# Altium schematic sheet Nano.SchDoc(Nano)
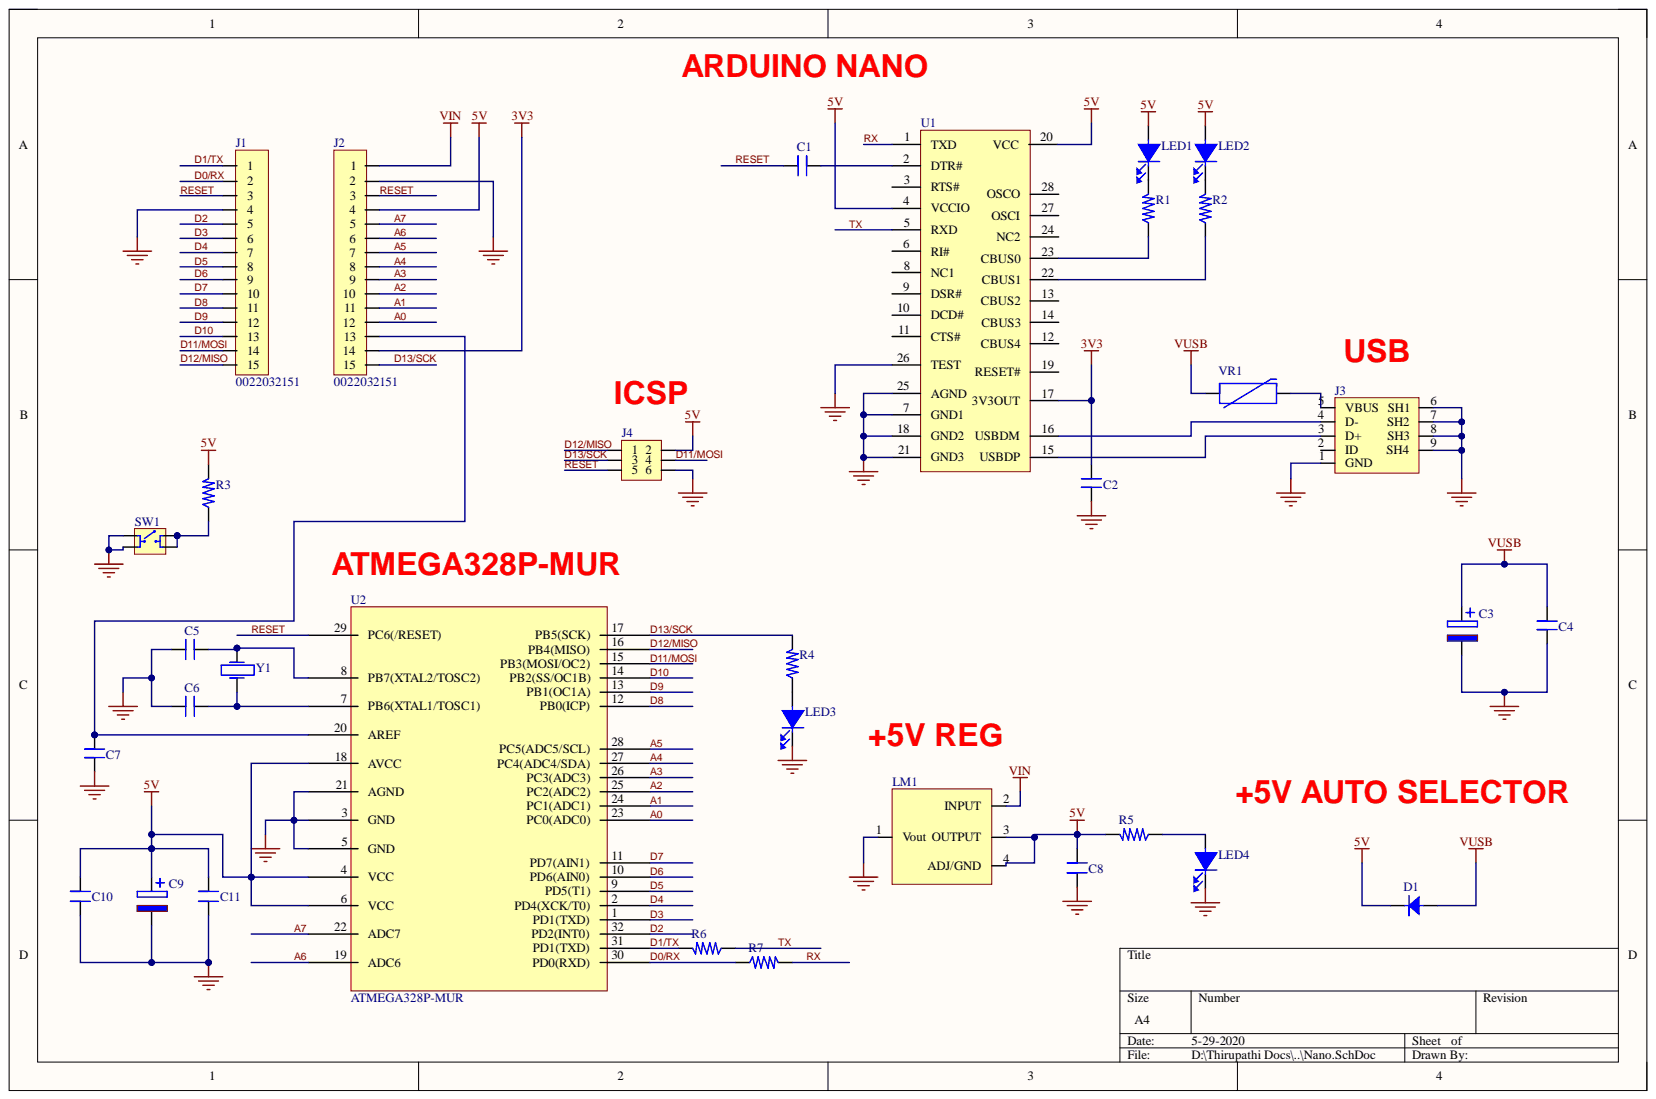
[diagram p0: Nano.SchDoc]

## Parts
C1  C2012X7R1H104K085AA  [c0805]  (value not drawn)
C2  C2012X7R1H104K085AA  [c0805]  (value not drawn)
C3  CC0805KKX5R7BB475  [c0805]  (value not drawn)
C4  C2012X7R1H104K085AA  [c0805]  (value not drawn)
C5  C2012X7R1H104K085AA  [c0805]  (value not drawn)
C6  C2012X7R1H104K085AA  [c0805]  (value not drawn)
C7  C2012X7R1H104K085AA  [c0805]  (value not drawn)
C8  CC0805JKX7R7BB105  [c0805]  (value not drawn)
C9  CC0805KKX5R7BB475  [c0805]  (value not drawn)
C10  CC0805JKX7R7BB105  [c0805]  (value not drawn)
C11  CC0805JKX7R7BB105  [c0805]  (value not drawn)
D1  MSS1P3L-M3/89A  [diode]  (value not drawn)
J1  0022032151  [header1*15]
J2  0022032151  [header1*15]
J3  1734035-2  [USB]  (value not drawn)
J4  67997-106HLF  [HEADER 2*3]  (value not drawn)
LED1  LGL29K-G2J1-24-Z  [LED]  (value not drawn)
LED2  LGL29K-G2J1-24-Z  [LED]  (value not drawn)
LED3  LGL29K-G2J1-24-Z  [LED]  (value not drawn)
LED4  LGL29K-G2J1-24-Z  [LED]  (value not drawn)
LM1  LM1117IMPX-5.0/NOPB  [SOP4]  (value not drawn)
R1  SR0805JR-7W1KL  [R0805]  (value not drawn)
R2  SR0805JR-7W1KL  [R0805]  (value not drawn)
R3  SR0805JR-7W1KL  [R0805]  (value not drawn)
R4  SR0805JR-7W1KL  [R0805]  (value not drawn)
R5  SR0805JR-7W1KL  [R0805]  (value not drawn)
R6  SR0805JR-7W1KL  [R0805]  (value not drawn)
R7  SR0805JR-7W1KL  [R0805]  (value not drawn)
SW1  1825910-6  [SWITCH]  (value not drawn)
U1  Voltage: 5V  [USB CONVERTER]  (hidden comment: FT232RL-REEL)
U2  ATMEGA328P-MUR  [atmega328p-mur]
VR1  CG0603MLC-05E  [R0603]  (value not drawn)
Y1  ABM7-16.000MHZ-D2Y-T  [16MHz crystall]  (value not drawn)

## Nets
3V3: C2.2 J2.14 U1.17(3V3OUT)
5V: C8.2 C9.1 C10.2 C11.2 D1.2 J2.4 J4.2 LED1.1 LED2.1 LM1.3(OUTPUT) LM1.4(ADJ/GND) R3.2 R5.2 U1.4(VCCIO) U1.20(VCC) U2.4(VCC) U2.6(VCC) U2.18(AVCC)
GND: C2.1 C3.2 C4.1 C5.1 C6.1 C7.1 C8.1 C9.2 C10.1 C11.1 J1.4 J2.2 J3.1(GND) J3.6(SH1) J3.7(SH2) J3.8(SH3) J3.9(SH4) J4.6 LED3.2 LED4.2 LM1.1(Vout) SW1.1 SW1.2 U1.7(GND1) U1.18(GND2) U1.21(GND3) U1.25(AGND) U1.26(TEST) U2.3(GND) U2.5(GND) U2.21(AGND)
VIN: J2.1 LM1.2(INPUT)
VUSB: C3.1 C4.2 D1.1 VR1.1
A0: J2.12 U2.23(PC0(ADC0))
A1: J2.11 U2.24(PC1(ADC1))
A2: J2.10 U2.25(PC2(ADC2))
A3: J2.9 U2.26(PC3(ADC3))
A4: J2.8 U2.27(PC4(ADC4/SDA))
A5: J2.7 U2.28(PC5(ADC5/SCL))
A6: J2.6 U2.19(ADC6)
A7: J2.5 U2.22(ADC7)
D0/RX: J1.2 R7.1 U2.30(PD0(RXD))
D1/TX: J1.1 R6.2 U2.31(PD1(TXD))
D2: J1.5 U2.32(PD2(INT0))
D3: J1.6 U2.1(PD1(TXD))
D4: J1.7 U2.2(PD4(XCK/T0))
D5: J1.8 U2.9(PD5(T1))
D6: J1.9 U2.10(PD6(AIN0))
D7: J1.10 U2.11(PD7(AIN1))
D8: J1.11 U2.12(PB0(ICP))
D9: J1.12 U2.13(PB1(OC1A))
D10: J1.13 U2.14(PB2(SS/OC1B))
D11/MOSI: J1.14 J4.4 U2.15(PB3(MOSI/OC2))
D12/MISO: J1.15 J4.1 U2.16(PB4(MISO))
D13/SCK: J2.15 J4.3 R4.2 U2.17(PB5(SCK))
RESET: C1.1 J1.3 J2.3 J4.5 U2.29(PC6(/RESET))
RX: R7.2 U1.1(TXD)
TX: R6.1 U1.5(RXD)
NetC1_2: C1.2 U1.2(DTR#)
NetC5_2: C5.2 U2.8(PB7(XTAL2/TOSC2)) Y1.2
NetC6_2: C6.2 U2.7(PB6(XTAL1/TOSC1)) Y1.1
NetC7_2: C7.2 J2.13 U2.20(AREF)
NetJ3_3: J3.3(D+) U1.15(USBDP)
NetJ3_4: J3.4(D-) U1.16(USBDM)
NetJ3_5: J3.5(VBUS) VR1.2
NetLED1_2: LED1.2 R1.2
NetLED2_2: LED2.2 R2.2
NetLED3_1: LED3.1 R4.1
NetLED4_1: LED4.1 R5.1
NetR1_1: R1.1 U1.23(CBUS0)
NetR2_1: R2.1 U1.22(CBUS1)
NetR3_1: R3.1 SW1.3 SW1.4

Not connected: J3 2(ID); U1 3(RTS#) 6(RI#) 8(NC1) 9(DSR#) 10(DCD#) 11(CTS#) 12(CBUS4) 13(CBUS2) 14(CBUS3) 19(RESET#) 24(NC2) 27(OSCI) 28(OSCO)

## Library data of the parts
C1 C2 C4-C7 = C2012X7R1H104K085AA: TDK - C2012X7R1H104K085AA - SMD Multilayer Ceramic Capacitor, 0.1 µF, 50 V, 0805 [2012 Metric], ± 10%, X7R, C Series; Capacitance: 100nF; Voltage: 50V; Case Code (Imperial): 0805; Case Code (Metric): 2012; Case/Package: 0805; Category: Ceramic Capacitors; Component Kind: Standard; ComponentLink1Description: https://datasheet.ciiva.com/11788/0900766b80c1bb00-11788128.pdf?src-supplier=RSComponents; ComponentLink1URL: https://datasheet.ciiva.com/11788/0900766b80c1bb00-11788128.pdf?src-supplier=RSComponents; ComponentLink2Description: https://datasheet.ciiva.com/6250/tdkmlcccaprange-6250821.pdf?src-supplier=Digi-Key; ComponentLink2URL: https://datasheet.ciiva.com/6250/tdkmlcccaprange-6250821.pdf?src-supplier=Digi-Key; ComponentLink3Description: https://datasheet.ciiva.com/24191/e412-c-24191447.pdf?src-supplier=Future+Electronics; ComponentLink3URL: https://datasheet.ciiva.com/24191/e412-c-24191447.pdf?src-supplier=Future+Electronics; ComponentLink4Description: https://datasheet.ciiva.com/6250/tdkmlcccaprange-6250824.pdf?src-supplier=Digi-Key; ComponentLink4URL: https://datasheet.ciiva.com/6250/tdkmlcccaprange-6250824.pdf?src-supplier=Digi-Key; ComponentLink5Description: https://datasheet.ciiva.com/23803/1796654-23803035.pdf?src-supplier=Newark; ComponentLink5URL: https://datasheet.ciiva.com/23803/1796654-23803035.pdf?src-supplier=Newark; ComponentLink6Description: https://datasheet.ciiva.com/28602/1571323-28602204.pdf?src-supplier=Element14; ComponentLink6URL: https://datasheet.ciiva.com/28602/1571323-28602204.pdf?src-supplier=Element14; Composition: Ceramic; Depth: 1.25mm; Dielectric: X7R; Dielectric Material: Ceramic; Height: 0.85mm; Lead Free: Lead Free; Length: 2mm; Library Name: Nano.SchLib; Manufacturer: TDK; Manufacturer Part Number: C2012X7R1H104K085AA; Max Operating Temperature: 125°C; Min Operating Temperature: -55°C; Mount: Surface Mount; Number of Pins: 2; Package Quantity: 1; Packaging: Tape and Reel; Pricing 1: 1=0.17000, 10=0.11900, 100=0.05610, 500=0.03936, 1000=0.03258 (USD); Radiation Hardening: No; REACH SVHC: No SVHC; RoHS: Yes; Stock 1: 275214; Supplier 1: Digi-Key; Supplier Part Number 1: 445-7534-1-ND; Termination: SMD/SMT; Thickness: 0.037inch; Tolerance: 10%; Voltage Rating: 50V; Voltage Rating (DC): 50V; Weight: 0.000194oz; Width: 0.049inch
C3 C9 = CC0805KKX5R7BB475: CAP CER 4.7UF 16V X5R 0805; Manufacturer: Yageo; Dissipation Factor: 10%; Capacitance: 4.7uF; Case Code (Imperial): 0805; Case Code (Metric): 2012; Case/Package: 0805; Category: Ceramic Capacitors; Component Kind: Standard; ComponentLink1Description: http://components.arrow.com/part/dataview/0323_04166570?src-supplier=Arrow+Europe; ComponentLink1URL: http://components.arrow.com/part/dataview/0323_04166570?src-supplier=Arrow+Europe; ComponentLink2Description: http://www.mouser.com/ds/2/447/UPY-GPHC_X5R_4V-to-50V_24-1109650.pdf?src-supplier=Mouser; ComponentLink2URL: http://www.mouser.com/ds/2/447/UPY-GPHC_X5R_4V-to-50V_24-1109650.pdf?src-supplier=Mouser; ComponentLink3Description: http://www.yageo.com/documents/recent/UPY-GPHC_X5R_4V-to-50V_12.pdf?src-supplier=Avnet+Europe; ComponentLink3URL: http://www.yageo.com/documents/recent/UPY-GPHC_X5R_4V-to-50V_12.pdf?src-supplier=Avnet+Europe; ComponentLink4Description: http://www.yageo.com/documents/recent/UPY-GPHC_X5R_4V-to-50V_12.pdf?src-supplier=Avnet; ComponentLink4URL: http://www.yageo.com/documents/recent/UPY-GPHC_X5R_4V-to-50V_12.pdf?src-supplier=Avnet; ComponentLink5Description: http://www.yageo.com/documents/recent/UPY-GPHC_X5R_4V-to-50V_25.pdf?src-supplier=Digi-Key; ComponentLink5URL: http://www.yageo.com/documents/recent/UPY-GPHC_X5R_4V-to-50V_25.pdf?src-supplier=Digi-Key; Composition: Ceramic; Depth: 1.25mm; Dielectric: X5R; Halogen Free: Halogen Free; Height: 1.25mm; Lead Free: Lead Free; Length: 2mm; Library Name: Nano.SchLib; Manufacturer Part Number: CC0805KKX5R7BB475; Max Operating Temperature: 85°C; Min Operating Temperature: -55°C; Mount: Surface Mount; Packaging: Tape and Reel; Pricing 1: 1=0.52000, 10=0.36600, 100=0.24120, 500=0.17864, 1000=0.15184 (USD); Radiation Hardening: No; RoHS: Yes; Stock 1: 299582; Supplier 1: Digi-Key; Supplier Part Number 1: 311-1867-1-ND; Termination: SMD/SMT; Thickness: 0.057inch; Tolerance: 10%; Voltage Rating: 16V; Voltage Rating (DC): 16V; Width: 1.25mm
C8 C10 C11 = CC0805JKX7R7BB105: Cap Ceramic 1uF 16V X7R 5% SMD 0805 125C Blister T/R; Manufacturer: Yageo; Capacitance: 1uF; Case/Package: 0805; Category: Ceramic Capacitors; Component Kind: Standard; ComponentLink1Description: http://components.arrow.com/part/dataview/0323_00773731?src-supplier=Arrow+Europe; ComponentLink1URL: http://components.arrow.com/part/dataview/0323_00773731?src-supplier=Arrow+Europe; ComponentLink2Description: http://www.yageo.com/documents/recent/UPY-GPHC_X7R_6.3V-to-50V_18.pdf?src-supplier=Digi-Key; ComponentLink2URL: http://www.yageo.com/documents/recent/UPY-GPHC_X7R_6.3V-to-50V_18.pdf?src-supplier=Digi-Key; Depth: 1.25mm; Dielectric: X7R; Length: 2mm; Library Name: Nano.SchLib; Manufacturer Part Number: CC0805JKX7R7BB105; Max Operating Temperature: 125°C; Min Operating Temperature: -55°C; Packaging: Tape and Reel; Pricing 1: 1=0.26000, 10=0.17600, 100=0.09990, 500=0.07052, 1000=0.06170 (USD); RoHS: Yes; Stock 1: 6648; Supplier 1: Digi-Key; Supplier Part Number 1: 311-3497-1-ND; Tolerance: 5%
D1 = MSS1P3L-M3/89A: Schottky Diodes & Rectifiers 1.0 Amp 30 Volt; Manufacturer: Vishay Semiconductors; Average Rectified Current: 1A; Element Configuration: Single; Forward Voltage: 500mV; Max Forward Surge Current (Ifsm): 25A; Max Repetitive Reverse Voltage (Vrrm): 30V; Max Reverse Leakage Current: 250uA; Category: Rectifier Diodes; Component Kind: Standard; ComponentLink1Description: https://datasheet.ciiva.com/23642/mss1p3l-23642464.pdf?src-supplier=Newark; ComponentLink1URL: https://datasheet.ciiva.com/23642/mss1p3l-23642464.pdf?src-supplier=Newark; ComponentLink2Description: https://datasheet.ciiva.com/917/mss1p3l-917251.pdf?src-supplier=Digi-Key; ComponentLink2URL: https://datasheet.ciiva.com/917/mss1p3l-917251.pdf?src-supplier=Digi-Key; ComponentLink3Description: https://datasheet.ciiva.com/917/mss1p3l-917252.pdf?src-supplier=Digi-Key; ComponentLink3URL: https://datasheet.ciiva.com/917/mss1p3l-917252.pdf?src-supplier=Digi-Key; ComponentLink4Description: https://datasheet.ciiva.com/917/mss1p3l-917253.pdf?src-supplier=Digi-Key; ComponentLink4URL: https://datasheet.ciiva.com/917/mss1p3l-917253.pdf?src-supplier=Digi-Key; ComponentLink5Description: https://datasheet.ciiva.com/25934/mss1p3l-25934805.pdf?src-supplier=Avnet+Europe; ComponentLink5URL: https://datasheet.ciiva.com/25934/mss1p3l-25934805.pdf?src-supplier=Avnet+Europe; ComponentLink6Description: https://datasheet.ciiva.com/16464/mss1p3l-16464118.pdf?src-supplier=Future+Electronics; ComponentLink6URL: https://datasheet.ciiva.com/16464/mss1p3l-16464118.pdf?src-supplier=Future+Electronics; ComponentLink7Description: https://datasheet.ciiva.com/8290/mss1p3l-8290391.pdf?src-supplier=Avnet; ComponentLink7URL: https://datasheet.ciiva.com/8290/mss1p3l-8290391.pdf?src-supplier=Avnet; ComponentLink8Description: https://datasheet.ciiva.com/7962/mss1p3l-7962344.pdf?src-supplier=Element14; ComponentLink8URL: https://datasheet.ciiva.com/7962/mss1p3l-7962344.pdf?src-supplier=Element14; Forward Current: 1A; Height: 0.018inch; Lead Free: Lead Free; Length: 0.09inch; Library Name: Nano.SchLib; Manufacturer Part Number: MSS1P3L-M3/89A; Max Operating Temperature: 150°C; Max Reverse Voltage (DC): 30V; Max Surge Current: 25A; Min Operating Temperature: -55°C; Mount: Surface Mount; Number of Pins: 2; Package Quantity: 1; Packaging: Tape and Reel; Peak Non-Repetitive Surge Current: 25A; Peak Reverse Current: 250uA; Pricing 1: 1=0.26000, 10=0.21500, 25=0.18000, 100=0.08800, 250=0.08660, 500=0.07340, 1000=0.05100 (USD); Radiation Hardening: No; REACH SVHC: Unknown; RoHS: Yes; Stock 1: 191443; Supplier 1: Digi-Key; Supplier Part Number 1: MSS1P3L-M3/89AGICT-ND; Width: 0.055inch
J1 J2 = 0022032151: Conn Unshrouded Header HDR 15 POS 2.54mm Solder ST Thru-Hole KK® Bag; Manufacturer: Molex; Connector Type: Male Pin; Contact Resistance: 10mR; Gender: Male; Number of Contacts: 15; Category: Headers and Wire Housings; Color: Natural; Component Kind: Standard; ComponentLink1Description: https://datasheet.ciiva.com/711/022032041-sd-711929.pdf?src-supplier=Digi-Key; ComponentLink1URL: https://datasheet.ciiva.com/711/022032041-sd-711929.pdf?src-supplier=Digi-Key; ComponentLink2Description: https://datasheet.ciiva.com/26843/getdatasheetpartid-350869-26843973.pdf?src-supplier=Verical; ComponentLink2URL: https://datasheet.ciiva.com/26843/getdatasheetpartid-350869-26843973.pdf?src-supplier=Verical; Contact Material: Brass; Contact Plating: Tin,Copper; Depth: 2.34mm; Housing Color: White; Housing Material: Nylon; Length: 37.85mm; Library Name: Nano.SchLib; Manufacturer Part Number: 0022032151; Max Operating Temperature: 75°C; Min Operating Temperature: 0°C; Mount: Through Hole; Number of Positions: 15; Number of Rows: 1; Orientation: Straight; Package Quantity: 250; Packaging: Bulk; Pitch: 2.54mm; Pricing 1: 1=1.36000, 10=1.19300, 100=1.02920, 500=0.84206, 1000=0.74850, 5000=0.65493, 10000=0.62219 (USD); RoHS: Yes; Stock 1: 487; Supplier 1: Digi-Key; Supplier Part Number 1: WM2783-ND; Termination: Solder
J3 = 1734035-2: TE CONNECTIVITY - 1734035-2 - USB Connector, Mini USB Type B, USB 2.0, Receptacle, 5 Positions, Surface Mount, Right Angle; Circuit Application: Power; Connector System: Cable-to-Board; Contact Current Rating: 1A; Insulation Resistance: 100MR; Number of Contacts: 5; Number of Ports: 1; PCB Thickness: 1.57mm; Pitch: 0.8mm; Plating: Nickel; Plating Thickness: 0.76um; USB Standard: USB 2.0; Category: Connectors; Color: Black; Component Kind: Standard; ComponentLink1Description: https://datasheet.ciiva.com/16292/1734035-2-16292193.pdf?src-supplier=Future+Electronics; ComponentLink1URL: https://datasheet.ciiva.com/16292/1734035-2-16292193.pdf?src-supplier=Future+Electronics; ComponentLink2Description: https://datasheet.ciiva.com/30172/ddecontrolleractionsrchrtrvdocnm117734536doctypedsdoclan-30172977.pdf?src-supplier=Onlinecomponents.com; ComponentLink2URL: https://datasheet.ciiva.com/30172/ddecontrolleractionsrchrtrvdocnm117734536doctypedsdoclan-30172977.pdf?src-supplier=Onlinecomponents.com; ComponentLink3Description: https://datasheet.ciiva.com/11759/0900766b80ed62f8-11759638.pdf?src-supplier=RSComponents; ComponentLink3URL: https://datasheet.ciiva.com/11759/0900766b80ed62f8-11759638.pdf?src-supplier=RSComponents; ComponentLink4Description: https://datasheet.ciiva.com/1098/1650952-1098129.pdf?src-supplier=Element14; ComponentLink4URL: https://datasheet.ciiva.com/1098/1650952-1098129.pdf?src-supplier=Element14; ComponentLink5Description: https://datasheet.ciiva.com/3094/eng-cd-1734035-a-3094354.pdf?src-supplier=Avnet; ComponentLink5URL: https://datasheet.ciiva.com/3094/eng-cd-1734035-a-3094354.pdf?src-supplier=Avnet; ComponentLink6Description: https://datasheet.ciiva.com/8015/1650952-8015098.pdf?src-supplier=Element14; ComponentLink6URL: https://datasheet.ciiva.com/8015/1650952-8015098.pdf?src-supplier=Element14; ComponentLink7Description: https://datasheet.ciiva.com/16545/eng-cd-1734035-a-16545803.pdf?src-supplier=Avnet+Europe; ComponentLink7URL: https://datasheet.ciiva.com/16545/eng-cd-1734035-a-16545803.pdf?src-supplier=Avnet+Europe; ComponentLink8Description: https://datasheet.ciiva.com/851/eng-cd-1734035-e1-c-1734035-851068.pdf?src-supplier=Digi-Key; ComponentLink8URL: https://datasheet.ciiva.com/851/eng-cd-1734035-e1-c-1734035-851068.pdf?src-supplier=Digi-Key; ComponentLink9Description: https://datasheet.ciiva.com/851/eng-cd-1734035-e1-c-1734035-851069.pdf?src-supplier=Digi-Key; ComponentLink9URL: https://datasheet.ciiva.com/851/eng-cd-1734035-e1-c-1734035-851069.pdf?src-supplier=Digi-Key; ComponentLink10Description: https://datasheet.ciiva.com/851/eng-cd-1734035-e1-c-1734035-851070.pdf?src-supplier=Digi-Key; ComponentLink10URL: https://datasheet.ciiva.com/851/eng-cd-1734035-e1-c-1734035-851070.pdf?src-supplier=Digi-Key; Connector Type: Receptacle; Contact Material: Bronze; Contact Plating: Gold; ELV: Compliant; Flammability Rating: UL94 V-0; Gender: Receptacle; Housing Color: Black; Housing Material: Thermoplastic; Lead Free: Lead Free; Length: 8.45mm; Library Name: Nano.SchLib; Manufacturer: TE Connectivity; Manufacturer Part Number: 1734035-2; Max Operating Temperature: 85°C; Min Operating Temperature: -40°C; Mount: Surface Mount,Horizontal; Number of Positions: 5; Number of Terminals: 5; Orientation: Right Angle; Package Quantity: 700; Packaging: Tape and Reel; Pricing 1: 1=1.81000, 10=1.63800, 25=1.53760, 50=1.47080, 100=1.40400, 250=1.27032 (USD); Radiation Hardening: No; REACH SVHC: No SVHC; RoHS: Yes; Stock 1: 19008; Supplier 1: Digi-Key; Supplier Part Number 1: A31727CT-ND; Termination: SMD/SMT; Width: 7.7mm
J4 = 67997-106HLF: CONN HEADER 6POS .100 STR 30AU; Manufacturer: Amphenol FCI; Connector Type: Male Pin; Contact Post Length: 3.05mm; Current Rating: 3A; Gender: Male; Insulation Resistance: 5GR; Max Voltage Rating (AC): 1.5kV; Number of Contacts: 6; Pitch: 2.54mm; Row Spacing: 0.1inch; Category: Headers and Wire Housings; Color: Black; Component Kind: Standard; ComponentLink1Description: https://datasheet.ciiva.com/5153/67996-222463-5153820.pdf?src-supplier=Mouser; ComponentLink1URL: https://datasheet.ciiva.com/5153/67996-222463-5153820.pdf?src-supplier=Mouser; ComponentLink2Description: https://datasheet.ciiva.com/16726/67996-16726286.pdf?src-supplier=Avnet+Europe; ComponentLink2URL: https://datasheet.ciiva.com/16726/67996-16726286.pdf?src-supplier=Avnet+Europe; ComponentLink3Description: https://datasheet.ciiva.com/722/67996-722044.pdf?src-supplier=Digi-Key; ComponentLink3URL: https://datasheet.ciiva.com/722/67996-722044.pdf?src-supplier=Digi-Key; ComponentLink4Description: https://datasheet.ciiva.com/10149/67996-10149221.pdf?src-supplier=Avnet; ComponentLink4URL: https://datasheet.ciiva.com/10149/67996-10149221.pdf?src-supplier=Avnet; ComponentLink5Description: https://datasheet.ciiva.com/46393/1876884-46393067.pdf?src-supplier=Element14; ComponentLink5URL: https://datasheet.ciiva.com/46393/1876884-46393067.pdf?src-supplier=Element14; Contact Gender: Male; Contact Material: Bronze; Contact Mating Length: 0.23inch; Contact Plating: Gold,GXT; Depth: 4.83mm; Flammability Rating: UL94 V-0; Housing Color: Black; Housing Material: Thermoplastic; Lead Free: Lead Free; Length: 7.62mm; Library Name: Nano.SchLib; Manufacturer Part Number: 67997-106HLF; Max Operating Temperature: 125°C; Min Operating Temperature: -65°C; Mount: Through Hole; Number of Positions: 6; Number of Rows: 2; Orientation: Straight; Package Quantity: 100; Packaging: Bulk; Pricing 1: 1=1.78000, 10=1.61400, 100=1.38370, 500=1.15308, 1000=0.98835, 5000=0.87634, 10000=0.85657 (USD); Radiation Hardening: No; REACH SVHC: No SVHC; RoHS: Yes; Stock 1: 1915; Supplier 1: Digi-Key; Supplier Part Number 1: 609-3393-ND; Termination: Through Hole; Voltage Rating: 1.5kV
LED1-LED4 = LGL29K-G2J1-24-Z: LED Uni-Color Green 572nm 2-Pin Chip 0603(1608Metric) T/R; Manufacturer: Osram Opto; Dominant Wavelength: 570nm; Forward Current: 20mA; Forward Voltage: 2.2V; Lens Color: White; Lens Size: 0.8mm; Lens Style: Flat,Diffused,Colorless,Rectangular; Lens Transparency: Diffused; Luminous Flux: 0.012lm; Luminous Intensity: 5.6mcd; Number of Elements: 1; Reverse Voltage: 12V; Test Current: 2mA; Viewing Angle: 160°; Case Code (Imperial): 0603; Case Code (Metric): 1608; Case/Package: 0603; Category: Uncategorized; Color: Green; Component Kind: Standard; ComponentLink1Description: https://datasheet.ciiva.com/4689/g-l29k-39844-4689584.pdf?src-supplier=Mouser; ComponentLink1URL: https://datasheet.ciiva.com/4689/g-l29k-39844-4689584.pdf?src-supplier=Mouser; ComponentLink2Description: https://datasheet.ciiva.com/15198/getdatasheetpartid-571127-15198411.pdf?src-supplier=Verical; ComponentLink2URL: https://datasheet.ciiva.com/15198/getdatasheetpartid-571127-15198411.pdf?src-supplier=Verical; ComponentLink3Description: https://datasheet.ciiva.com/2112/00077107-0-2112886.pdf?src-supplier=Digi-Key; ComponentLink3URL: https://datasheet.ciiva.com/2112/00077107-0-2112886.pdf?src-supplier=Digi-Key; ComponentLink4Description: https://datasheet.ciiva.com/2112/00077107-0-2112887.pdf?src-supplier=Digi-Key; ComponentLink4URL: https://datasheet.ciiva.com/2112/00077107-0-2112887.pdf?src-supplier=Digi-Key; ComponentLink5Description: https://datasheet.ciiva.com/2112/00077107-0-2112888.pdf?src-supplier=Digi-Key; ComponentLink5URL: https://datasheet.ciiva.com/2112/00077107-0-2112888.pdf?src-supplier=Digi-Key; Depth: 0.8mm; Height: 0.65mm; Illumination Color: Green; Length: 1.3mm; Library Name: Nano.SchLib; Manufacturer Part Number: LGL29K-G2J1-24-Z; Max Operating Temperature: 100°C; Min Operating Temperature: -40°C; Mount: Surface Mount; Number of LEDs: 1; Number of Pins: 2; Package Quantity: 5000; Packaging: Tape and Reel; Pricing 1: 1=0.42000, 10=0.30400, 100=0.19830, 500=0.14250, 1000=0.11153, 2500=0.10224 (USD); Radiation Hardening: No; RoHS: Yes; Stock 1: 154569; Supplier 1: Digi-Key; Supplier Part Number 1: 475-2709-1-ND; Width: 0.8mm
LM1 = LM1117IMPX-5.0/NOPB: TEXAS INSTRUMENTS - LM1117IMPX-5.0/NOPB - LDO VOLTAGE REGULATOR, FIXED, 5V, 800mA, SOT-223-4, FULL REEL; Manufacturer: TI National Semiconductor; Accuracy: 1%; Dropout Voltage: 1.2V; Max Input Voltage: 15V; Min Input Voltage: 2.6V; Number of Outputs: 1; Polarity: Positive; Quiescent Current: 15mA; Case/Package: SOT-223; Category: Voltage Regulators - Linear; Component Kind: Standard; ComponentLink1Description: https://datasheet.ciiva.com/22735/1882372-22735013.pdf?src-supplier=Element14; ComponentLink1URL: https://datasheet.ciiva.com/22735/1882372-22735013.pdf?src-supplier=Element14; ComponentLink2Description: https://datasheet.ciiva.com/6591/lm1117-n-6591866.pdf?src-supplier=Digi-Key; ComponentLink2URL: https://datasheet.ciiva.com/6591/lm1117-n-6591866.pdf?src-supplier=Digi-Key; ComponentLink3Description: https://datasheet.ciiva.com/6591/lm1117-n-6591868.pdf?src-supplier=Digi-Key; ComponentLink3URL: https://datasheet.ciiva.com/6591/lm1117-n-6591868.pdf?src-supplier=Digi-Key; ComponentLink4Description: https://datasheet.ciiva.com/6591/lm1117-n-6591869.pdf?src-supplier=Digi-Key; ComponentLink4URL: https://datasheet.ciiva.com/6591/lm1117-n-6591869.pdf?src-supplier=Digi-Key; ComponentLink5Description: https://datasheet.ciiva.com/46384/1882372-46384846.pdf?src-supplier=Element14; ComponentLink5URL: https://datasheet.ciiva.com/46384/1882372-46384846.pdf?src-supplier=Element14; ComponentLink6Description: https://datasheet.ciiva.com/3223/lm1117-n-3223519.pdf?src-supplier=Avnet; ComponentLink6URL: https://datasheet.ciiva.com/3223/lm1117-n-3223519.pdf?src-supplier=Avnet; Contact Plating: Tin; Lead Free: Lead Free; Library Name: Nano.SchLib; Manufacturer Part Number: LM1117IMPX-5.0/NOPB; Max Operating Temperature: 125°C; Max Output Current: 800mA; Min Current Limit: 800mA; Min Operating Temperature: -40°C; Mount: Surface Mount; Nominal Output Voltage: 5V; Number of Pins: 4; Number of Regulators: 1; Output Current: 800mA; Output Type: Fixed; Output Voltage: 5V; Output Voltage Accuracy: 1%; Package Quantity: 2000; Packaging: Tape and Reel; Pricing 1: 1=1.10000, 10=0.98500, 25=0.93520, 100=0.71810, 250=0.63460, 500=0.60120, 1000=0.46760 (USD); Radiation Hardening: No; REACH SVHC: No SVHC; RoHS: Yes; Stock 1: 5336; Supplier 1: Digi-Key; Supplier Part Number 1: LM1117IMPX-5.0/NOPBCT-ND
R1-R7 = SR0805JR-7W1KL: Surge Chip Resistor Automotive Grade Thick Film 0805 1kOhm 5% Paper T/R; Manufacturer: Yageo; Category: Chip SMD Resistors; Component Kind: Standard; Library Name: Nano.SchLib; Manufacturer Part Number: SR0805JR-7W1KL; Pricing 1: 1=0.26000, 10=0.22100, 100=0.08640, 1000=0.03618, 2500=0.03316 (USD); RoHS: No; Stock 1: 9118; Supplier 1: Digi-Key; Supplier Part Number 1: YAG6171CT-ND
SW1 = 1825910-6: SWITCH TACTILE SPST-NO 0.05A 24V; Manufacturer: TE Connectivity Alcoswitch; Actuator Length: 0.055inch; Actuator Material: PBT; Actuator Type: Standard; Contact Current Rating: 50mA; Contact Resistance: 100mR; Electrical Life: 100000Cycles; Illumination Type: Non-Illuminated,Illuminated; Operating Force: 160gf; Switch Function: Off-Mom; Switch Travel: 0.25mm; Throw Configuration: SPST; Category: Pushbutton Switches; Component Kind: Standard; ComponentLink1Description: https://datasheet.ciiva.com/30199/1825910-6rqpn1825910-6-30199520.pdf?src-supplier=Onlinecomponents.com; ComponentLink1URL: https://datasheet.ciiva.com/30199/1825910-6rqpn1825910-6-30199520.pdf?src-supplier=Onlinecomponents.com; ComponentLink2Description: https://datasheet.ciiva.com/15184/getdatasheetpartid-395915-15184509.pdf?src-supplier=Verical; ComponentLink2URL: https://datasheet.ciiva.com/15184/getdatasheetpartid-395915-15184509.pdf?src-supplier=Verical; Contact Material: Copper Alloy; Contact Plating: Silver; ELV: Compliant; Lead Free: Lead Free; Length: 5.99mm; Library Name: Nano.SchLib; Manufacturer Part Number: 1825910-6; Max Current Rating: 50mA; Max Operating Temperature: 85°C; Max Voltage Rating (DC): 24V; Mechanical Life: 100000Cycles; Min Operating Temperature: -35°C; Mount: Through Hole; Orientation: Straight; Package Quantity: 750; Packaging: Loose Piece; Pricing 1: 1=0.10000, 10=0.10100, 25=0.09560, 100=0.08700, 250=0.08180, 500=0.07484, 1000=0.06265, 2500=0.06092, 5000=0.05743 (USD); REACH SVHC: Unknown; RoHS: Yes; Stock 1: 35673; Supplier 1: Digi-Key; Supplier Part Number 1: 450-1650-ND; Termination: PC Pins; Type: Switch
U1 = FT232RL-REEL: FTDI - FT232RL-REEL - Interface Bridges, USB to UART, 1.8 V, 5.25 V, SSOP, 28 Pins, -40 °C; Data Rate: 12Mbps; Interface: UART,RS-232,RS-422,RS-485; Max Supply Voltage: 5.25V; Min Supply Voltage: 3.3V; Number of Channels: 1; Operating Supply Current: 15mA; Case/Package: SSOP; Category: Interface ICs; Component Kind: Standard; ComponentLink1Description: https://datasheet.ciiva.com/34304/2161019-34304054.pdf?src-supplier=Jameco; ComponentLink1URL: https://datasheet.ciiva.com/34304/2161019-34304054.pdf?src-supplier=Jameco; ComponentLink2Description: https://datasheet.ciiva.com/4787/ds-ft232r-11534-4787658.pdf?src-supplier=Mouser; ComponentLink2URL: https://datasheet.ciiva.com/4787/ds-ft232r-11534-4787658.pdf?src-supplier=Mouser; ComponentLink3Description: https://datasheet.ciiva.com/26841/ft232rl-reel-26841080.pdf?src-supplier=IIIC; ComponentLink3URL: https://datasheet.ciiva.com/26841/ft232rl-reel-26841080.pdf?src-supplier=IIIC; ComponentLink4Description: https://datasheet.ciiva.com/1787/ds-ft232r-1787047.pdf?src-supplier=Digi-Key; ComponentLink4URL: https://datasheet.ciiva.com/1787/ds-ft232r-1787047.pdf?src-supplier=Digi-Key; ComponentLink5Description: https://datasheet.ciiva.com/1787/ds-ft232r-1787048.pdf?src-supplier=Digi-Key; ComponentLink5URL: https://datasheet.ciiva.com/1787/ds-ft232r-1787048.pdf?src-supplier=Digi-Key; ComponentLink6Description: https://datasheet.ciiva.com/1787/ds-ft232r-1787049.pdf?src-supplier=Digi-Key; ComponentLink6URL: https://datasheet.ciiva.com/1787/ds-ft232r-1787049.pdf?src-supplier=Digi-Key; ComponentLink7Description: https://datasheet.ciiva.com/15150/getdatasheetpartid-643207-15150506.pdf?src-supplier=Verical; ComponentLink7URL: https://datasheet.ciiva.com/15150/getdatasheetpartid-643207-15150506.pdf?src-supplier=Verical; ComponentLink8Description: https://datasheet.ciiva.com/16200/ds-ft232r-16200652.pdf?src-supplier=Future+Electronics; ComponentLink8URL: https://datasheet.ciiva.com/16200/ds-ft232r-16200652.pdf?src-supplier=Future+Electronics; Height: 1.75mm; Lead Free: Lead Free; Length: 10.2mm; Library Name: Nano.SchLib; Manufacturer: FTDI; Manufacturer Part Number: FT232RL-REEL; Max Operating Temperature: 85°C; Min Operating Temperature: -40°C; Mount: Surface Mount; Number of Pins: 28; Number of Transceivers: 1; Package Quantity: 2000; Packaging: Tape and Reel; Pricing 1: 1=4.50000, 10=4.05000, 50=3.85000, 100=3.65000, 250=3.25000, 500=2.85002, 1000=2.65000 (USD); Radiation Hardening: No; REACH SVHC: No SVHC; RoHS: Yes; Stock 1: 43040; Supplier 1: Digi-Key; Supplier Part Number 1: 768-1007-6-ND; Weight: 0.080001oz; Width: 5.3mm
U2 = ATMEGA328P-MUR: ATMEL         ATMEGA328P-MUR             8 Bit Microcontroller, Low Power High Performance, ATmega, 20 MHz, 32 KB, 2 KB, 32 Pins, MLF; Manufacturer: Microchip / Atmel; Core Architecture: AVR; Data Bus Width: 8b; Frequency: 20MHz; Interface: I2C,SPI,UART,USART,2-Wire; Max Supply Voltage: 5.5V; Memory Size: 32KB; Memory Type: FLASH; Min Supply Voltage: 1.8V; Number of I/Os: 23; Number of Timers/Counters: 3; Oscillator Type: Internal; Peripherals: Brown-out Detect/Reset,POR,PWM,WDT; RAM Size: 2KB; Watchdog Timer: Yes; Case/Package: QFN EP; Category: Microcontrollers; Component Kind: Standard; ComponentLink1Description: http://www.mouser.com/ds/2/36/atmel-8271-8-bit-avr-microcontroller-atmega48a-48p-365589.pdf?src-supplier=Mouser; ComponentLink1URL: http://www.mouser.com/ds/2/36/atmel-8271-8-bit-avr-microcontroller-atmega48a-48p-365589.pdf?src-supplier=Mouser; ComponentLink2Description: http://components.arrow.com/part/dataview/0323_02278738?src-supplier=Arrow+Europe; ComponentLink2URL: http://components.arrow.com/part/dataview/0323_02278738?src-supplier=Arrow+Europe; ComponentLink3Description: http://www.produktinfo.conrad.com/datenblaetter/1200000-1299999/001266984-da-01-en-IC_MCU_8BIT_ATMEGA328P_MUR_VFQFN_32_ATM.pdf?src-supplier=Conrad; ComponentLink3URL: http://www.produktinfo.conrad.com/datenblaetter/1200000-1299999/001266984-da-01-en-IC_MCU_8BIT_ATMEGA328P_MUR_VFQFN_32_ATM.pdf?src-supplier=Conrad; ComponentLink4Description: http://components.arrow.com/part/dataview/48719205S8832643N3744?src-supplier=Arrow; ComponentLink4URL: http://components.arrow.com/part/dataview/48719205S8832643N3744?src-supplier=Arrow; ComponentLink5Description: http://www.farnell.com/datasheets/2047852.pdf?src-supplier=Element14; ComponentLink5URL: http://www.farnell.com/datasheets/2047852.pdf?src-supplier=Element14; ComponentLink6Description: http://www.microchip.com/mymicrochip/filehandler.aspx?ddocname=en589968&src-supplier=Digi-Key; ComponentLink6URL: http://www.microchip.com/mymicrochip/filehandler.aspx?ddocname=en589968&src-supplier=Digi-Key; ComponentLink7Description: http://www.atmel.com/Images/Atmel-8271-8-bit-AVR-Microcontroller-ATmega48A-48PA-88A-88PA-168A-168PA-328-328P_datasheet.pdf?src-supplier=Avnet; ComponentLink7URL: http://www.atmel.com/Images/Atmel-8271-8-bit-AVR-Microcontroller-ATmega48A-48PA-88A-88PA-168A-168PA-328-328P_datasheet.pdf?src-supplier=Avnet; ComponentLink8Description: http://www.atmel.com/Images/Atmel-8271-8-bit-AVR-Microcontroller-ATmega48A-48PA-88A-88PA-168A-168PA-328-328P_datasheet.pdf?src-supplier=Avnet+Europe; ComponentLink8URL: http://www.atmel.com/Images/Atmel-8271-8-bit-AVR-Microcontroller-ATmega48A-48PA-88A-88PA-168A-168PA-328-328P_datasheet.pdf?src-supplier=Avnet+Europe; Contact Plating: Tin,Matte; Lead Free: Lead Free; Library Name: Nano.SchLib; Manufacturer Part Number: ATMEGA328P-MUR; Max Frequency: 20MHz; Max Operating Temperature: 85°C; Min Operating Temperature: -40°C; Mount: Surface Mount; Number of Pins: 32; Number of Programmable I/O: 23; Package Quantity: 6000; Packaging: Tape and Reel; Pricing 1: 1=2.08000, 25=1.91000, 100=1.73000 (USD); REACH SVHC: No SVHC; RoHS: Yes; Supplier 1: Digi-Key; Supplier Part Number 1: ATMEGA328P-MURCT-ND
VR1 = CG0603MLC-05E: VARISTOR 0603; Manufacturer: Bourns; Capacitance: 0.5pF; Clamping Voltage: 20V; Current Rating: 50nA; Element Configuration: Single; Max Voltage Rating (DC): 5V; Number of Channels: 1; Turn-On Delay Time: 1ns; Voltage Rating (AC): 8V; Case Code (Imperial): 0603; Case Code (Metric): 1608; Case/Package: 0603; Category: Varistors; Component Kind: Standard; ComponentLink1Description: https://datasheet.ciiva.com/27747/1163231-27747629.pdf?src-supplier=Jameco; ComponentLink1URL: https://datasheet.ciiva.com/27747/1163231-27747629.pdf?src-supplier=Jameco; ComponentLink2Description: https://datasheet.ciiva.com/30070/mlc-30070503.pdf?src-supplier=Onlinecomponents.com; ComponentLink2URL: https://datasheet.ciiva.com/30070/mlc-30070503.pdf?src-supplier=Onlinecomponents.com; ComponentLink3Description: https://datasheet.ciiva.com/6991/mlc-6991832.pdf?src-supplier=Digi-Key; ComponentLink3URL: https://datasheet.ciiva.com/6991/mlc-6991832.pdf?src-supplier=Digi-Key; ComponentLink4Description: https://datasheet.ciiva.com/6991/mlc-6991834.pdf?src-supplier=Digi-Key; ComponentLink4URL: https://datasheet.ciiva.com/6991/mlc-6991834.pdf?src-supplier=Digi-Key; ComponentLink5Description: https://datasheet.ciiva.com/6991/mlc-6991836.pdf?src-supplier=Digi-Key; ComponentLink5URL: https://datasheet.ciiva.com/6991/mlc-6991836.pdf?src-supplier=Digi-Key; ComponentLink6Description: https://datasheet.ciiva.com/1278/1785244-1278701.pdf?src-supplier=Element14; ComponentLink6URL: https://datasheet.ciiva.com/1278/1785244-1278701.pdf?src-supplier=Element14; ComponentLink7Description: https://datasheet.ciiva.com/22696/1785244-22696318.pdf?src-supplier=Element14; ComponentLink7URL: https://datasheet.ciiva.com/22696/1785244-22696318.pdf?src-supplier=Element14; ComponentLink8Description: https://datasheet.ciiva.com/25958/mlc-25958222.pdf?src-supplier=Avnet+Europe; ComponentLink8URL: https://datasheet.ciiva.com/25958/mlc-25958222.pdf?src-supplier=Avnet+Europe; ComponentLink9Description: https://datasheet.ciiva.com/8084/mlc-8084047.pdf?src-supplier=Avnet; ComponentLink9URL: https://datasheet.ciiva.com/8084/mlc-8084047.pdf?src-supplier=Avnet; Contact Plating: Tin; Depth: 0.8mm; Leakage Current: 50nA; Length: 1.6mm; Library Name: Nano.SchLib; Manufacturer Part Number: CG0603MLC-05E; Max Operating Temperature: 85°C; Min Operating Temperature: -40°C; Number of Circuits: 1; Number of Pins: 2; Operating Supply Voltage: 5V; Package Quantity: 1; Packaging: Tape and Reel; Pricing 1: 1=0.51000, 10=0.35900, 25=0.29440, 100=0.23570, 250=0.17140, 500=0.13926, 1000=0.10712, 2500=0.09641 (USD); Radiation Hardening: No; REACH SVHC: No SVHC; RoHS: Yes; Stock 1: 14561; Supplier 1: Digi-Key; Supplier Part Number 1: CG0603MLC-05ECT-ND; Termination: SMD/SMT; Thickness: 0.8mm; Voltage: 5V; Voltage Rating (DC): 5V; Width: 0.8mm; Working Voltage: 5V
Y1 = ABM7-16.000MHZ-D2Y-T: CRYSTAL 16.0000MHZ 18PF SMD; Manufacturer: Abracon; ESR (Equivalent Series Resistance): 50R; Frequency: 16MHz; Frequency Stability: 30ppm; Frequency Tolerance: 20ppm; Case/Package: CSMD; Category: Crystals; Component Kind: Standard; ComponentLink1Description: https://datasheet.ciiva.com/15116/getdatasheetpartid-721624-15116835.pdf?src-supplier=Verical; ComponentLink1URL: https://datasheet.ciiva.com/15116/getdatasheetpartid-721624-15116835.pdf?src-supplier=Verical; ComponentLink2Description: https://datasheet.ciiva.com/22658/947971-22658293.pdf?src-supplier=Element14; ComponentLink2URL: https://datasheet.ciiva.com/22658/947971-22658293.pdf?src-supplier=Element14; ComponentLink3Description: https://datasheet.ciiva.com/5788/abm7-5788054.pdf?src-supplier=Farnell; ComponentLink3URL: https://datasheet.ciiva.com/5788/abm7-5788054.pdf?src-supplier=Farnell; ComponentLink4Description: https://datasheet.ciiva.com/7998/947971-7998600.pdf?src-supplier=Element14; ComponentLink4URL: https://datasheet.ciiva.com/7998/947971-7998600.pdf?src-supplier=Element14; ComponentLink5Description: https://datasheet.ciiva.com/46385/947971-46385243.pdf?src-supplier=Element14; ComponentLink5URL: https://datasheet.ciiva.com/46385/947971-46385243.pdf?src-supplier=Element14; ComponentLink6Description: https://datasheet.ciiva.com/16383/abm7-16383174.pdf?src-supplier=Future+Electronics; ComponentLink6URL: https://datasheet.ciiva.com/16383/abm7-16383174.pdf?src-supplier=Future+Electronics; ComponentLink7Description: https://datasheet.ciiva.com/18649/abm7-18649865.pdf?src-supplier=Digi-Key; ComponentLink7URL: https://datasheet.ciiva.com/18649/abm7-18649865.pdf?src-supplier=Digi-Key; ComponentLink8Description: https://datasheet.ciiva.com/18649/abm7-18649866.pdf?src-supplier=Digi-Key; ComponentLink8URL: https://datasheet.ciiva.com/18649/abm7-18649866.pdf?src-supplier=Digi-Key; ComponentLink9Description: https://datasheet.ciiva.com/18649/abm7-18649867.pdf?src-supplier=Digi-Key; ComponentLink9URL: https://datasheet.ciiva.com/18649/abm7-18649867.pdf?src-supplier=Digi-Key; ComponentLink10Description: https://datasheet.ciiva.com/26941/getdatasheetpartid-719818-26941724.pdf?src-supplier=Verical; ComponentLink10URL: https://datasheet.ciiva.com/26941/getdatasheetpartid-719818-26941724.pdf?src-supplier=Verical; Composition: Ceramic; Depth: 3.5mm; Height: 0.055inch; Lead Free: Lead Free; Length: 6mm; Library Name: Nano.SchLib; Load Capacitance: 18pF; Manufacturer Part Number: ABM7-16.000MHZ-D2Y-T; Max Operating Temperature: 85°C; Min Operating Temperature: -40°C; Mount: Surface Mount; Number of Pins: 2; Package Quantity: 1000; Packaging: Tape and Reel; Pricing 1: 1=1.00000, 10=0.88600, 50=0.82780, 100=0.73150, 500=0.69300 (USD); Radiation Hardening: No; REACH SVHC: Unknown; RoHS: Yes; Stock 1: 66009; Supplier 1: Digi-Key; Supplier Part Number 1: 535-9840-1-ND; Termination: SMD/SMT; Tolerance: 20ppm; Width: 3.3mm
